# Altium schematic sheet HV_circuits.SchDoc(HV_circuits)
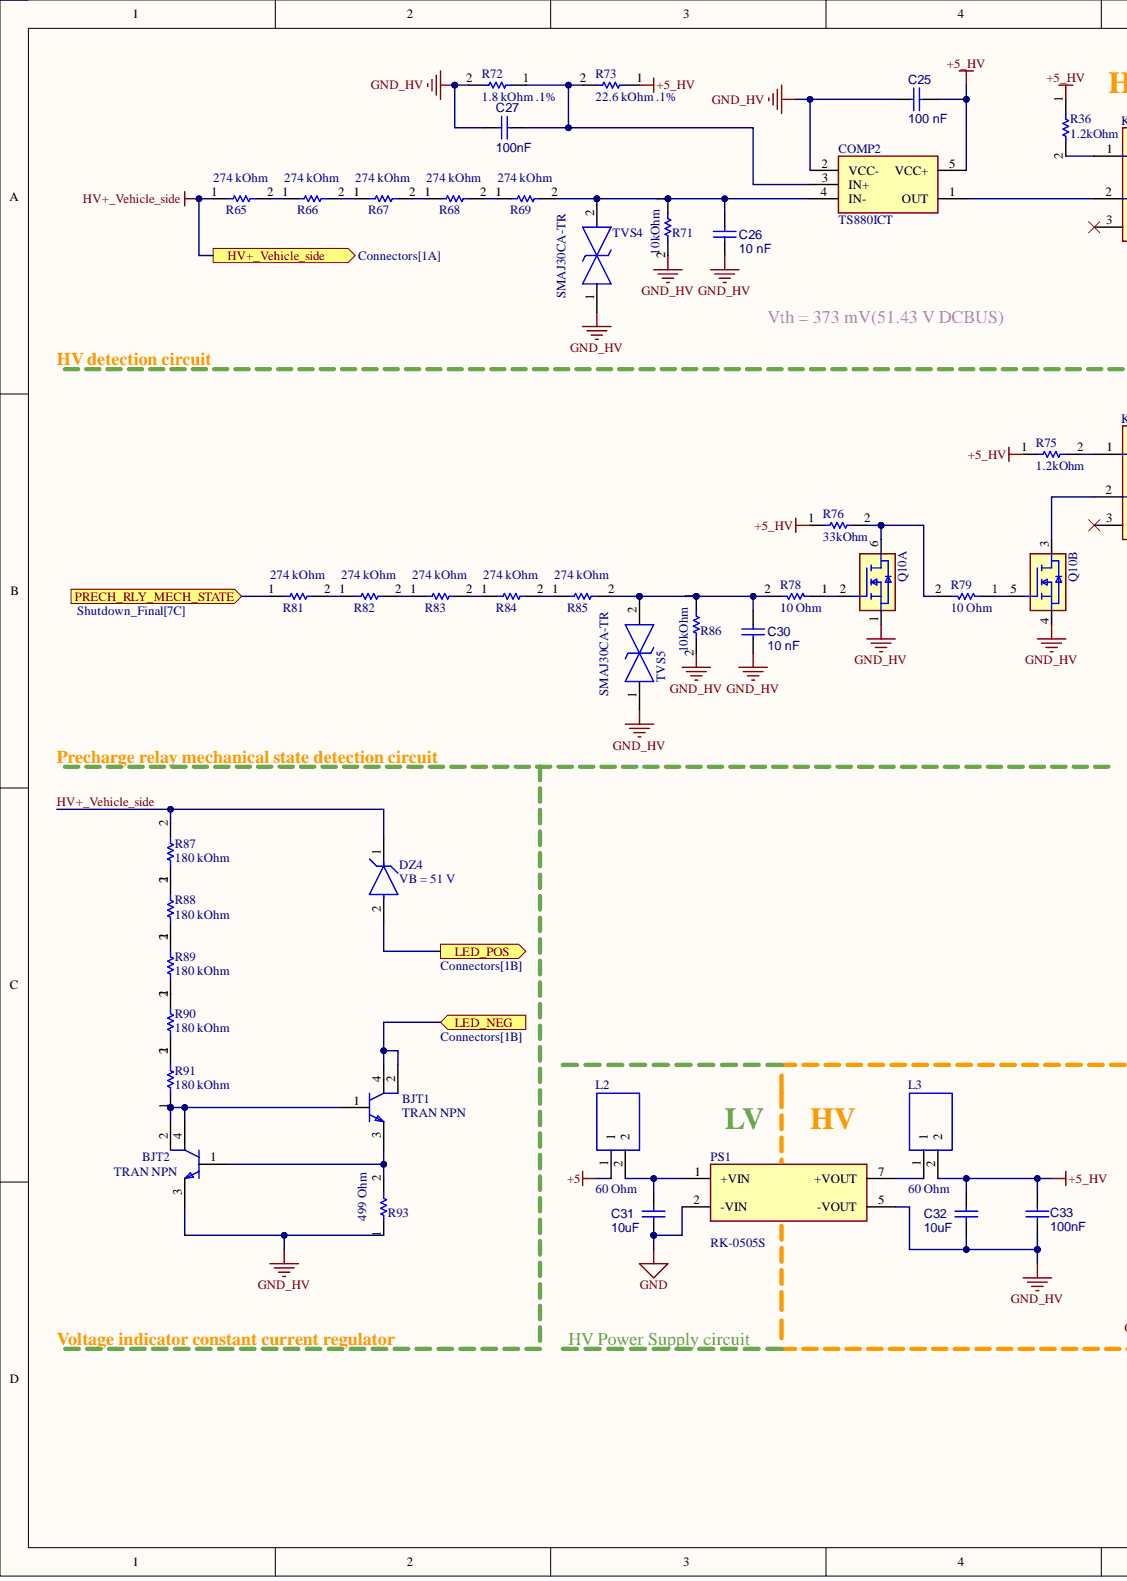
[diagram p0: HV_circuits.SchDoc, left]
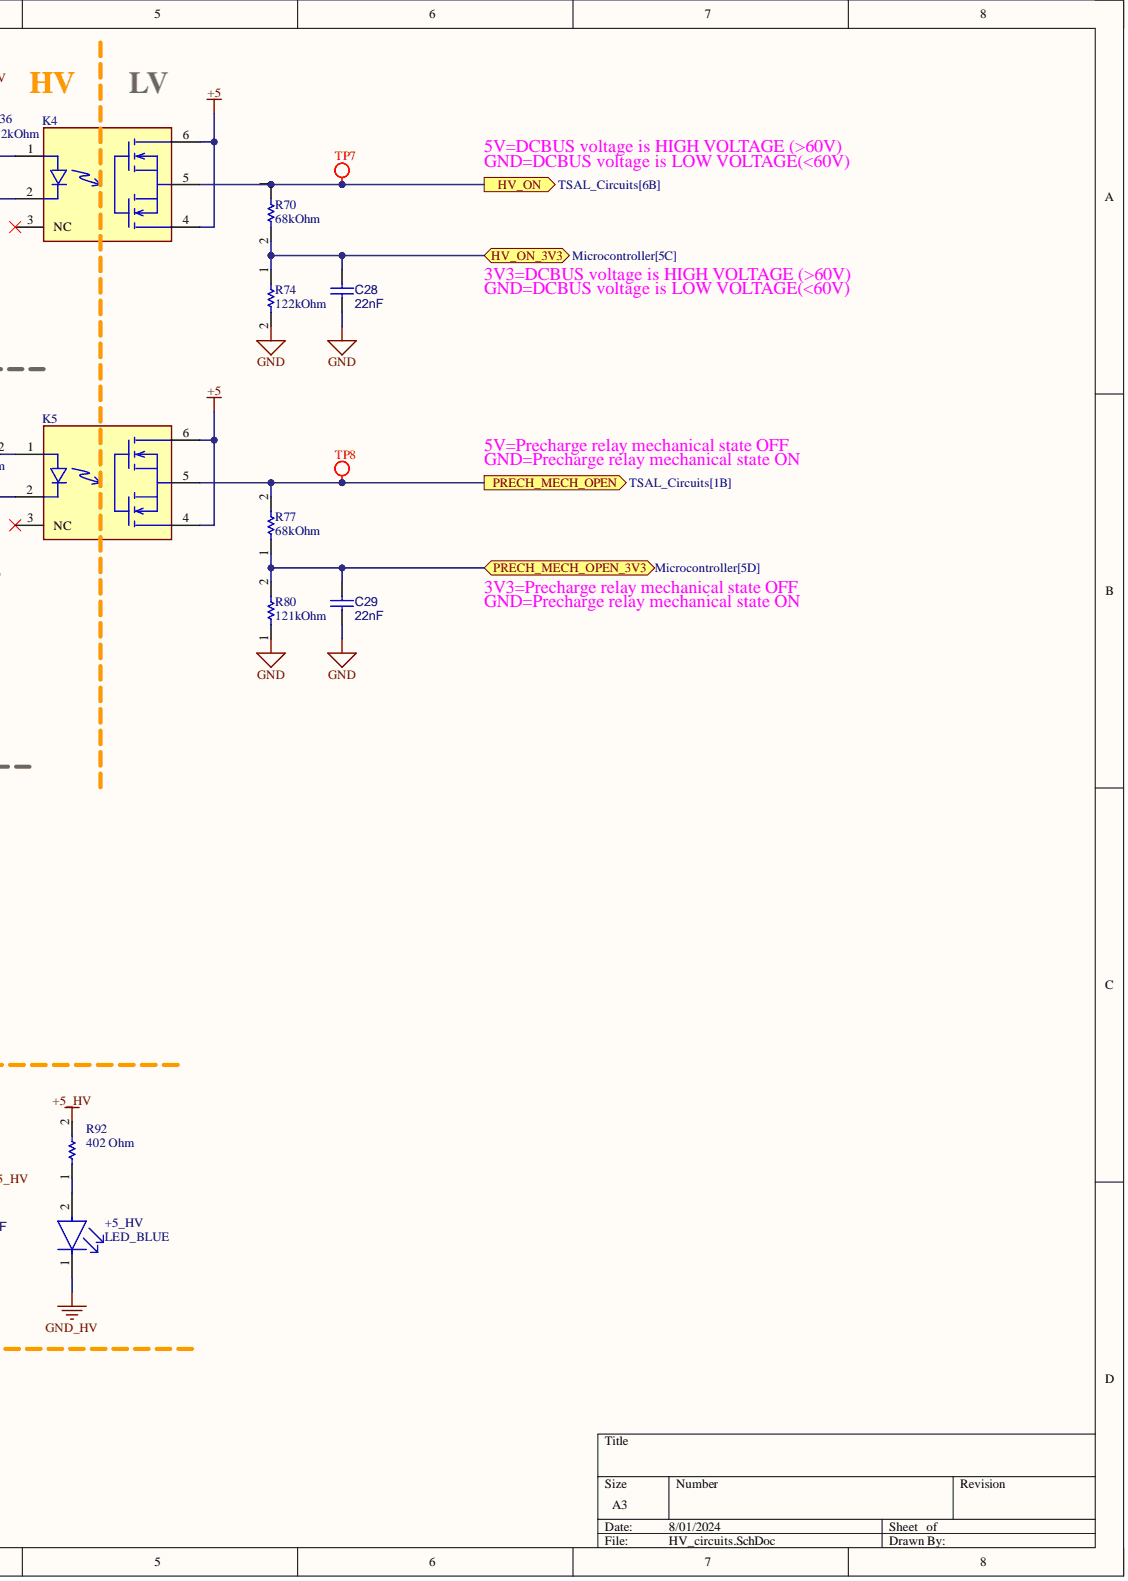
[diagram p1: HV_circuits.SchDoc, right]

## Parts
+5_HV  LED_BLUE
BJT1  TRAN NPN
BJT2  TRAN NPN  Polarity: NPN
C25  100 nF
C26  10 nF
C27  100nF
C28  22nF
C29  22nF
C30  10 nF
C31  10uF
C32  10uF
C33  100nF
COMP2  TS880ICT
DZ4  VB = 51 V
K4  Solid-State Relay  (value not drawn)
K5  Solid-State Relay  (value not drawn)
L2  60 Ohm
L3  60 Ohm
PS1  RK-0505S
Q10  SI1926DL-T1-GE3  (value not drawn)  (parts A,B)
R36  1.2kOhm
R65  274 kOhm
R66  274 kOhm
R67  274 kOhm
R68  274 kOhm
R69  274 kOhm
R70  68kOhm
R71  10kOhm
R72  1.8 kOhm .1%
R73  22.6 kOhm .1%
R74  122kOhm
R75  1.2kOhm
R76  33kOhm
R77  68kOhm
R78  10 Ohm
R79  10 Ohm
R80  121kOhm
R81  274 kOhm
R82  274 kOhm
R83  274 kOhm
R84  274 kOhm
R85  274 kOhm
R86  10kOhm
R87  180 kOhm
R88  180 kOhm
R89  180 kOhm
R90  180 kOhm
R91  180 kOhm
R92  402 Ohm
R93  499 Ohm
TP7  Test Point  (value not drawn)
TP8  Test Point  (value not drawn)
TVS4  SMAJ30CA-TR
TVS5  SMAJ30CA-TR

## Ports
HV+_Vehicle_side, HV_ON, HV_ON_3V3, LED_NEG, LED_POS, PRECH_MECH_OPEN, PRECH_MECH_OPEN_3V3, PRECH_RLY_MECH_STATE

## Nets
+5: K4.4 K4.6 K5.4 K5.6 L2.1
+5_HV: C25.1 C32.1 C33.1 COMP2.5(VCC+) L3.2 R36.1 R73.1 R75.1 R76.1 R92.2
GND: C28.1 C29.1 C31.2 PS1.2(-VIN) R74.2 R80.1
GND_HV: +5_HV.1 BJT2.3 C25.2 C26.1 C27.2 C30.1 C32.2 C33.2 COMP2.2(VCC-) PS1.5(-VOUT) Q10.1 Q10.4 R71.2 R72.2 R86.2 R93.1 TVS4.1 TVS5.1
HV+_Vehicle_side: DZ4.1 R65.1 R87.2 ; port HV+_Vehicle_side
HV_ON: K4.5 R70.1 TP7.1 ; port HV_ON
HV_ON_3V3: C28.2 R70.2 R74.1 ; port HV_ON_3V3
LED_NEG: BJT1.2 BJT1.4 ; port LED_NEG
LED_POS: DZ4.2 ; port LED_POS
PRECH_MECH_OPEN: K5.5 R77.2 TP8.1 ; port PRECH_MECH_OPEN
PRECH_MECH_OPEN_3V3: C29.2 R77.1 R80.2 ; port PRECH_MECH_OPEN_3V3
PRECH_RLY_MECH_STATE: R81.1 ; port PRECH_RLY_MECH_STATE
Net+5_HV_2: +5_HV.2 R92.1
NetBJT1_1: BJT1.1 BJT2.2 BJT2.4 R91.1
NetBJT1_3: BJT1.3 BJT2.1 R93.2
NetC26_2: C26.2 COMP2.4(IN-) R69.2 R71.1 TVS4.2
NetC27_1: C27.1 COMP2.3(IN+) R72.1 R73.2
NetC30_2: C30.2 R78.2 R85.2 R86.1 TVS5.2
NetC31_1: C31.1 L2.2 PS1.1(+VIN)
NetCOMP2_1: COMP2.1(OUT) K4.2
NetK4_1: K4.1 R36.2
NetK5_1: K5.1 R75.2
NetK5_2: K5.2 Q10.3
NetL3_1: L3.1 PS1.7(+VOUT)
NetQ10_2: Q10.2 R78.1
NetQ10_5: Q10.5 R79.1
NetQ10_6: Q10.6 R76.2 R79.2
NetR65_2: R65.2 R66.1
NetR66_2: R66.2 R67.1
NetR67_2: R67.2 R68.1
NetR68_2: R68.2 R69.1
NetR81_2: R81.2 R82.1
NetR82_2: R82.2 R83.1
NetR83_2: R83.2 R84.1
NetR84_2: R84.2 R85.1
NetR87_1: R87.1 R88.2
NetR88_1: R88.1 R89.2
NetR89_1: R89.1 R90.2
NetR90_1: R90.1 R91.2

Not connected: K4 3(NC); K5 3(NC)

## Library data of the parts
+5_HV = CMP-007-00036-2: VLMB1300-GS08; Tech: SMD; Component Kind: Standard; Package: 0603; Library Name: EMEA- Squadra Corse PoliTO-  Politecnico di Torino
BJT1 BJT2 = CMP-12195-000013-1: STN0214; Collector Emitter Breakdown Voltage: 1.2kV; Collector Emitter Saturation Voltage: 300mV; Continuous Collector Current: 200mA; Element Configuration: Single; Emitter Base Voltage (VEBO): 6V; hFE Min: 3; Max Power Dissipation: 1.6W; Number of Elements: 1; Tech: SMD; Case/Package: SOT-223; Collector Emitter Voltage (VCEO): 1.2kV; Collector-emitter Voltage-Max: 300mV; Component Kind: Standard; ComponentLink1Description: Website; ComponentLink1URL: https://www.mouser.it/ProductDetail/STMicroelectronics/STN0214?qs=pmcUWs1B1IKOCzUPe9wAgg%3D%3D; Lead Free: Lead Free; Library Name: Altium Content Vault; Max Breakdown Voltage: 1.2kV; Max Collector Current: 200mA; Max Operating Temperature: 150°C; Min Operating Temperature: -65°C; Mount: Surface Mount; Number of Pins: 4; Package Quantity: 1; Packaging: Tape and Reel; Power Dissipation: 1.6W; Radiation Hardening: No; REACH SVHC: No SVHC; RoHS Compliant: Yes; Series: -
  BJT1: Polarity: NPN
C25 C27 = CMP-001-00085-5: VJ0805Y104MXATW1BC; Tech: SMD; Component Kind: Standard; ComponentLink1Description: Website; Package: 0805; Library Name: EMEA- Squadra Corse PoliTO-  Politecnico di Torino
C26 C30 = CMP-001-00085-5: VJ0805H103KXBBC31; Tech: SMD; Component Kind: Standard; ComponentLink1Description: Website; Package: 0805; Library Name: EMEA- Squadra Corse PoliTO-  Politecnico di Torino
C28 C29 = CMP-001-00085-5: VJ0805Y223JXACW1BC; Tech: SMD; Component Kind: Standard; ComponentLink1Description: Website; Package: 0805; Library Name: EMEA- Squadra Corse PoliTO-  Politecnico di Torino
C31 C32 = CMP-001-00085-5: GRM21BR71A106KA73K; Tech: SMD; Component Kind: Standard; ComponentLink1Description: Website; Package: 0805; Library Name: EMEA- Squadra Corse PoliTO-  Politecnico di Torino
C33 = CMP-001-00085-5: VJ0805Y104KBABE31; Tech: SMD; Component Kind: Standard; ComponentLink1Description: Website; Package: 0805; Library Name: EMEA- Squadra Corse PoliTO-  Politecnico di Torino
COMP2 = CMP-030-000037-4: Rail-to-rail 0.9V nanopower; Tech: SMD; Component Kind: Standard; Package: SC-70-5; Library Name: EMEA- Squadra Corse PoliTO-  Politecnico di Torino
DZ4 = CMP-56208-000009-1: SMAZ5942B-E3/61; Element Configuration: Single; Impedance: 5.5R; Max Power Dissipation: 1.5W; Max Reverse Leakage Current: 500nA; Test Current: 34.1mA; Tolerance: 5%; Voltage Rating (DC): 11V; Zener Voltage: 51V; Case/Package: DO-214AC; Component Kind: Standard; ComponentLink1Description: Website; ComponentLink1URL: https://www.mouser.it/ProductDetail/Vishay-General-Semiconductor/SMAZ5942B-E3-61?qs=SH0Geuu7vjcUsnhckh9I6Q%3D%3D; Lead Free: Lead Free; Library Name: Altium Content Vault; Max Operating Temperature: 150°C; Min Operating Temperature: -65°C; Mount: Surface Mount; Number of Pins: 2; Package Quantity: 1; Packaging: Tape and Reel; Power Dissipation: 1.5W; Power Rating: 1.5W; RoHS Compliant: Yes; Voltage Tolerance: 5%
K4 K5 = CMP-2000-07398-2: VO14642AABTR; Manufacturer: Vishay; Input Current: 50mA; Isolation Voltage: 5300Vrms; Max Junction Temp: +125°C; Max Power Dissipation: 330mW; Number Of Contacts: 1; On State Resistance: 0.25Ω; Output Current: 2A; Output Voltage: 60V; Packag: SMD DIP-6; Standoff Height: 0.05mm; Tech: SMD; Throw Configuration: SPST-NO; Ambient Temperature Range High: +85°C; Ambient Temperature Range Low: -55°C; Automotive: No; Category: Relay; Component Kind: Standard; ComponentLink2Description: Datasheet; ComponentLink2URL: http://www.vishay.com/docs/81646/vo14642a.pdf; ComponentLink3Description: Website; ComponentLink3URL: https://www.mouser.it/ProductDetail/Vishay-Semiconductors/VO14642AABTR?qs=%2Fjqivxn91cdjDkQHAFlOvw%3D%3D; Device Class L1: Electromechanical; Device Class L2: Relays; Device Class L3: Solid State Relays; Digikey Description: SSR RELAY SPST-NO 2A 0-60V; Height: 4.25mm; Ipc Land Pattern Name: SOIC254P980X425-6; Lead Free: Yes; Library Name: Altium Content Vault; Manufacturer Part Number: VO14642AABTR; Mouser Description: Solid State Relays - PCB Mount Normally Open Form 1A 60V; Octopart: https://octopart.com/vo14642aabtr-vishay-42763680; Package: VO14642AABTR; RoHS: TRUE; Temperature: to +125°C; Temperature Range High: +125°C
L2 L3 = CMP-056-000000-2: ILHB0805ER600V; Manufacturer_Name: Vishay; Geometry.Height: 1.1mm; Component Kind: Standard; Datasheet Link: https://www.arrow.com/en/products/ilhb0805er600v/vishay; Design Item ID: ILHB0805ER600V; Manufacturer_Part_Number: ILHB0805ER600V; Mouser Part Number: 70-ILHB0805ER600V; Mouser Price/Stock: https://www.mouser.co.uk/ProductDetail/Vishay-Dale/ILHB0805ER600V?qs=nDC8RkArV2CRAw2z9yWdfQ%3D%3D; Source: ILHB0805ER600V.SchLib; Library Name: EMEA- Squadra Corse PoliTO-  Politecnico di Torino
PS1 = CMP-035-000002-2: RECOM POWER - RK-0505S - Isolated Board Mount DC/DC Converter; Arrow Part Number: RK-0505S; Arrow Price/Stock: https://www.arrow.com/en/products/rk-0505s/recom-power; Component Kind: Standard; Datasheet Link: https://componentsearchengine.com/Datasheets/2/RK-0505S.pdf; Design Item ID: RK-0505S; Height: 10.45mm; Manufacturer_Name: RECOM Power; Manufacturer_Part_Number: RK-0505S; Mouser Part Number: 919-RK-0505S; Mouser Price/Stock: https://www.mouser.com/Search/Refine.aspx?Keyword=919-RK-0505S; Source: SamacSys.SchLib; Library Name: EMEA- Squadra Corse PoliTO-  Politecnico di Torino
Q10 = CMP-009-000004-2: MOSFET (N-Channel); Manufacturer_Name: Vishay; Arrow Part Number: SI1926DL-T1-GE3; Arrow Price/Stock: https://www.arrow.com/en/products/si1926dl-t1-ge3/vishay; Component Kind: Standard; Datasheet Link: https://www.vishay.com/docs/73684/si1926dl.pdf; Design Item ID: SI1926DL-T1-GE3; Height: 1.1mm; Manufacturer_Part_Number: SI1926DL-T1-GE3; Mouser Part Number: 78-SI1926DL-T1-GE3; Mouser Price/Stock: https://www.mouser.com/Search/Refine.aspx?Keyword=78-SI1926DL-T1-GE3; Source: SamacSys.SchLib; Library Name: EMEA- Squadra Corse PoliTO-  Politecnico di Torino
R36 R75 = CMP-009-00179-5: CRCW06031K20FKEAHP; Tech: SMD; Component Kind: Standard; ComponentLink1Description: Website; Package: 0603; Library Name: EMEA- Squadra Corse PoliTO-  Politecnico di Torino
R65-R69 R81-R85 = CMP-009-00181-1: TNPW1206274KBEEA; Tech: SMD; Component Kind: Standard; ComponentLink1Description: Website; ComponentLink1URL: https://www.mouser.it/ProductDetail/Vishay-Beyschlag/MCA12060D2743BP100?qs=hjSuqQECij%2F2NG89e2917g%3D%3D; Package: 1206; Library Name: EMEA- Squadra Corse PoliTO-  Politecnico di Torino
R70 R77 = CMP-009-00179-5: CRCW060368K0FKEAC; Tech: SMD; Component Kind: Standard; ComponentLink1Description: Website; Package: 0603; Library Name: EMEA- Squadra Corse PoliTO-  Politecnico di Torino
R71 R86 = CMP-009-00179-5: CRCW060310K0FKEAHP; Tech: SMD; Component Kind: Standard; ComponentLink1Description: Website; Package: 0603; Library Name: EMEA- Squadra Corse PoliTO-  Politecnico di Torino
R72 = CMP-009-00179-5: TNPW06031K82BEEA; Tech: SMD; Component Kind: Standard; ComponentLink1Description: Website; Package: 0603; Library Name: EMEA- Squadra Corse PoliTO-  Politecnico di Torino
R73 = CMP-009-00179-5: TNPW060322K6BEEA; Tech: SMD; Component Kind: Standard; ComponentLink1Description: Website; Package: 0603; Library Name: EMEA- Squadra Corse PoliTO-  Politecnico di Torino
R74 R80 = CMP-009-00179-5: CRCW0603121KFKEA; Tech: SMD; Component Kind: Standard; ComponentLink1Description: Website; Package: 0603; Library Name: EMEA- Squadra Corse PoliTO-  Politecnico di Torino
R76 = CMP-009-00179-5: CRCW060333K0FKEAC; Tech: SMD; Component Kind: Standard; ComponentLink1Description: Website; Package: 0603; Library Name: EMEA- Squadra Corse PoliTO-  Politecnico di Torino
R78 R79 = CMP-009-00179-5: CRCW060310R0FKEAHP; Tech: SMD; Component Kind: Standard; ComponentLink1Description: Website; Package: 0603; Library Name: EMEA- Squadra Corse PoliTO-  Politecnico di Torino
R87-R91 = CMP-009-00181-1: CRMA1206AF180KFKEF; Tech: SMD; Component Kind: Standard; ComponentLink1Description: Website; ComponentLink1URL: https://www.mouser.it/ProductDetail/Vishay/CRMA1206AF180KFKEF?qs=QNEnbhJQKvZoVL5AZkX8fA%3D%3D; Package: 1206; Library Name: EMEA- Squadra Corse PoliTO-  Politecnico di Torino
R92 = CMP-009-00179-5: CRCW0603402RFKEA; Tech: SMD; Component Kind: Standard; ComponentLink1Description: Website; Package: 0603; Library Name: EMEA- Squadra Corse PoliTO-  Politecnico di Torino
R93 = CMP-009-00179-5: CRCW0603499RFKEAHP; Tech: SMD; Component Kind: Standard; ComponentLink1Description: Website; Package: 0603; Library Name: EMEA- Squadra Corse PoliTO-  Politecnico di Torino
TP7 TP8 = CMP-002-00071-2: Component Kind: Standard; Description: Test Point; Library Name: EMEA- Squadra Corse PoliTO-  Politecnico di Torino
TVS4 TVS5 = CMP-004-000005-1: TVS Diode Bi-Directional SMAJ30CA-TR 64.3V; Manufacturer_Name: STMicroelectronics; Arrow Part Number: SMAJ30CA-TR; Arrow Price/Stock: https://www.arrow.com/en/products/smaj30ca-tr/stmicroelectronics?region=nac; Component Kind: Standard; Datasheet Link: https://www.st.com/resource/en/datasheet/smaj10ca.pdf; Design Item ID: SMAJ30CA-TR; Height: 2.65mm; Manufacturer_Part_Number: SMAJ30CA-TR; Mouser Part Number: 511-SMAJ30CA-TR; Mouser Price/Stock: https://www.mouser.co.uk/ProductDetail/STMicroelectronics/SMAJ30CA-TR?qs=0TJjK1waviDY0EkLaKH12g%3D%3D; Source: SamacSys.SchLib; Library Name: EMEA- Squadra Corse PoliTO-  Politecnico di Torino
